# Altium schematic sheet Sheet2.SchDoc
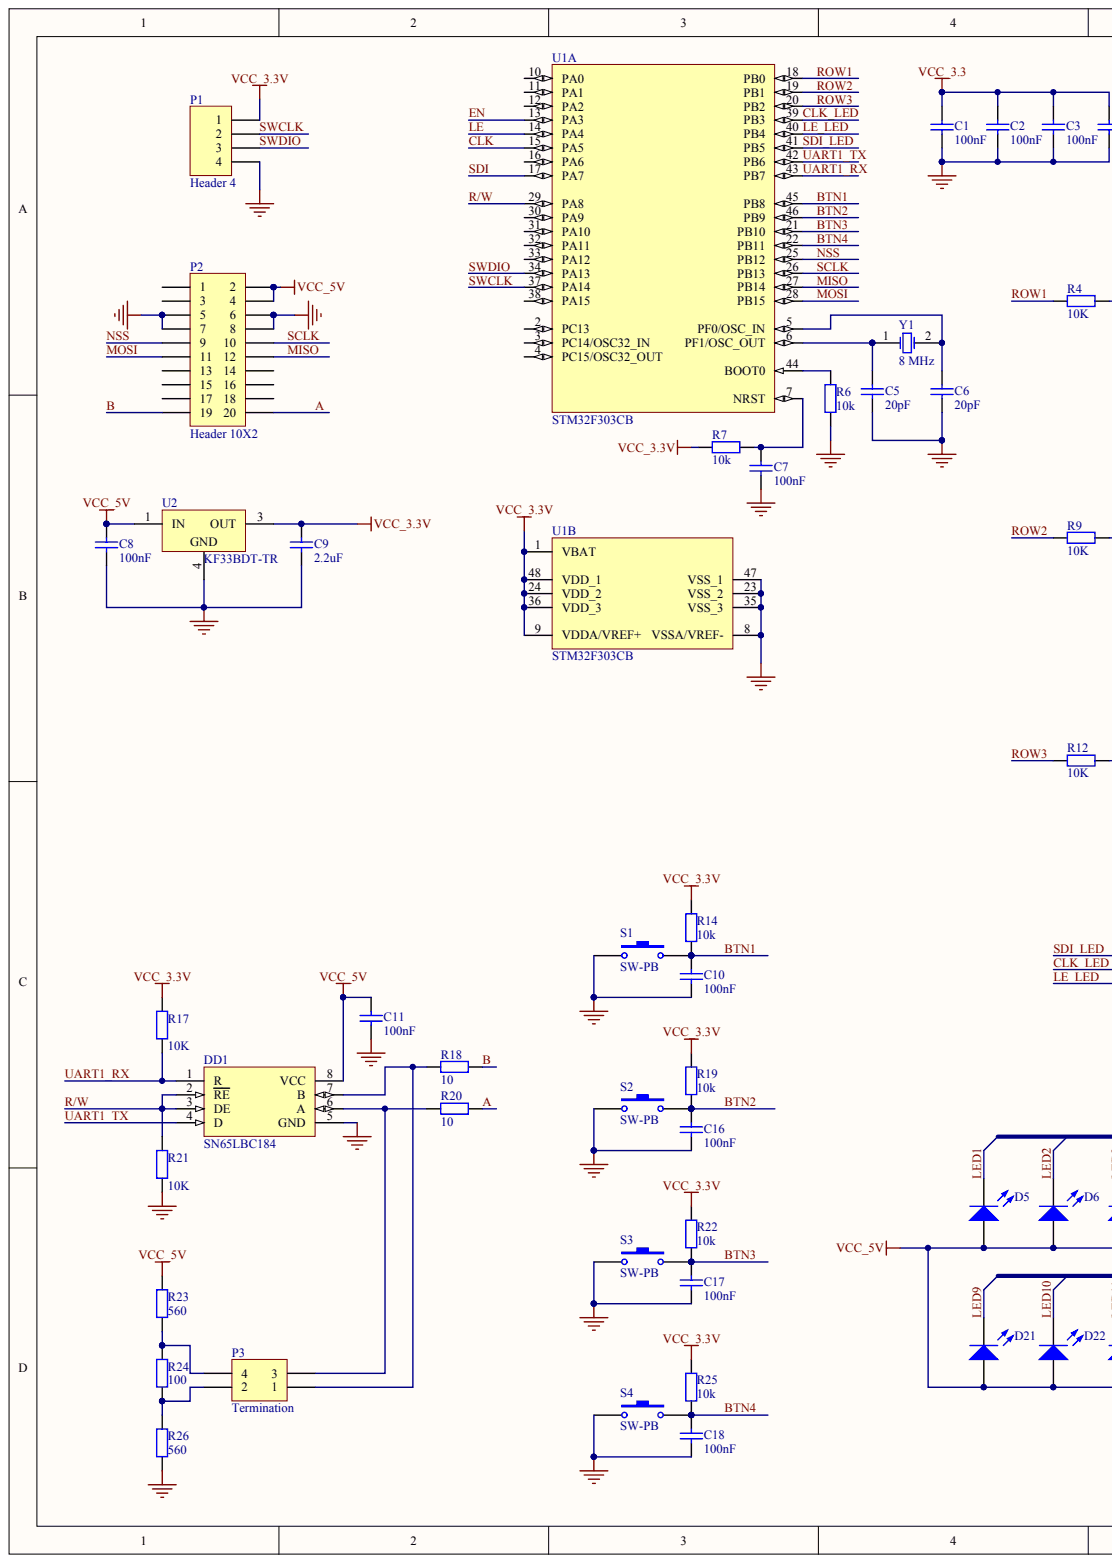
[diagram p0: Sheet2.SchDoc, left]
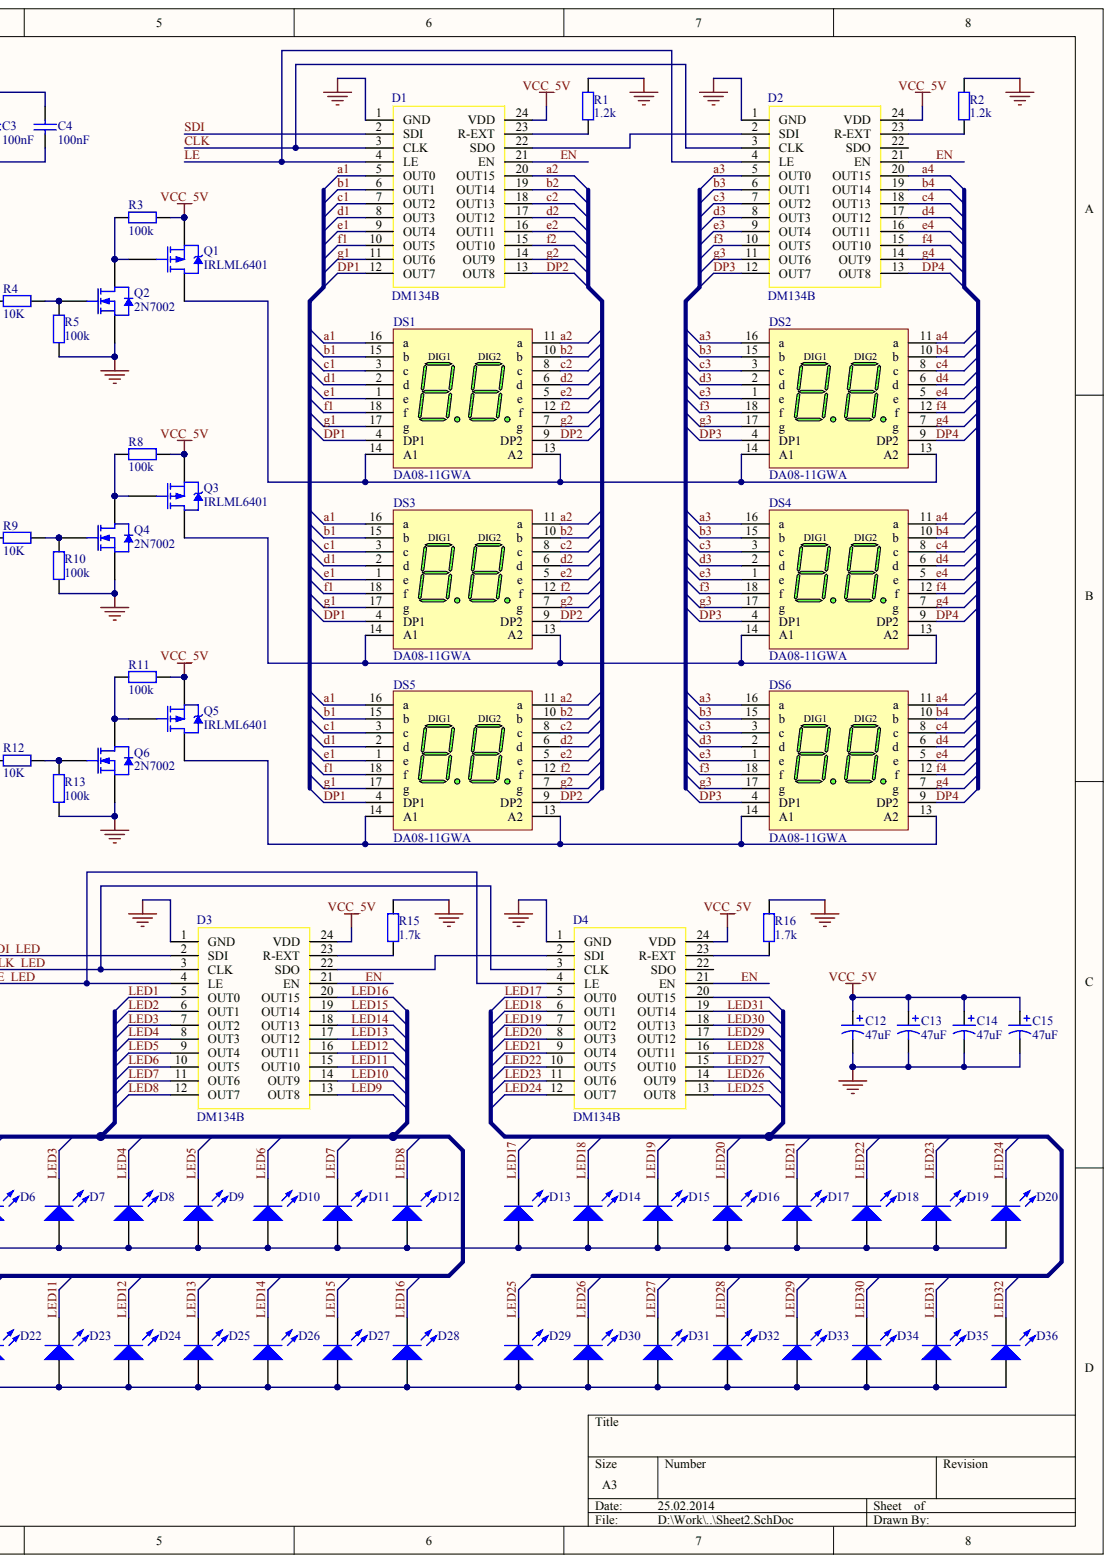
[diagram p1: Sheet2.SchDoc, right]

## Parts
C1  100nF
C2  100nF
C3  100nF
C4  100nF
C5  20pF
C6  20pF
C7  100nF
C8  100nF
C9  2.2uF
C10  100nF
C11  100nF
C12  47uF
C13  47uF
C14  47uF
C15  47uF
C16  100nF
C17  100nF
C18  100nF
D1  DM134B
D2  DM134B
D3  DM134B
D4  DM134B
D5  LED0  (value not drawn)
D6  LED0  (value not drawn)
D7  LED0  (value not drawn)
D8  LED0  (value not drawn)
D9  LED0  (value not drawn)
D10  LED0  (value not drawn)
D11  LED0  (value not drawn)
D12  LED0  (value not drawn)
D13  LED0  (value not drawn)
D14  LED0  (value not drawn)
D15  LED0  (value not drawn)
D16  LED0  (value not drawn)
D17  LED0  (value not drawn)
D18  LED0  (value not drawn)
D19  LED0  (value not drawn)
D20  LED0  (value not drawn)
D21  LED0  (value not drawn)
D22  LED0  (value not drawn)
D23  LED0  (value not drawn)
D24  LED0  (value not drawn)
D25  LED0  (value not drawn)
D26  LED0  (value not drawn)
D27  LED0  (value not drawn)
D28  LED0  (value not drawn)
D29  LED0  (value not drawn)
D30  LED0  (value not drawn)
D31  LED0  (value not drawn)
D32  LED0  (value not drawn)
D33  LED0  (value not drawn)
D34  LED0  (value not drawn)
D35  LED0  (value not drawn)
D36  LED0  (value not drawn)
DD1  SN65LBC184
DS1  DA08-11GWA
DS2  DA08-11GWA
DS3  DA08-11GWA
DS4  DA08-11GWA
DS5  DA08-11GWA
DS6  DA08-11GWA
P1  Header 4
P2  Header 10X2
P3  Termination
Q1  IRLML6401
Q2  2N7002
Q3  IRLML6401
Q4  2N7002
Q5  IRLML6401
Q6  2N7002
R1  1.2k
R2  1.2k
R3  100k
R4  10K
R5  100k
R6  10k
R7  10k
R8  100k
R9  10K
R10  100k
R11  100k
R12  10K
R13  100k
R14  10k
R15  1.7k
R16  1.7k
R17  value 10K  (hidden comment: Res2)
R18  value 10  (hidden comment: Res2)
R19  10k
R20  value 10  (hidden comment: Res2)
R21  value 10K  (hidden comment: Res2)
R22  10k
R23  value 560  (hidden comment: Res2)
R24  value 100  (hidden comment: Res2)
R25  10k
R26  value 560  (hidden comment: Res2)
S1  SW-PB
S2  SW-PB
S3  SW-PB
S4  SW-PB
U1  STM32F303CB  (parts A,B)
U2  KF33BDT-TR
Y1  8 MHz

## Nets
VCC_3.3: C1.2 C2.2 C3.2 C4.2
VCC_3.3V: C9.2 P1.1 R7.1 R14.1 R17.2 R19.1 R22.1 R25.1 U1.1(VBAT) U1.9(VDDA/VREF+) U1.24(VDD_2) U1.36(VDD_3) U1.48(VDD_1) U2.3
VCC_5V: C8.2 C11.1 C12.1 C13.1 C14.1 C15.1 D1.24(VDD) D2.24(VDD) D3.24(VDD) D4.24(VDD) D5.1 D6.1 D7.1 D8.1 D9.1 D10.1 D11.1 D12.1 D13.1 D14.1 D15.1 D16.1 D17.1 D18.1 D19.1 D20.1 D21.1 D22.1 D23.1 D24.1 D25.1 D26.1 D27.1 D28.1 D29.1 D30.1 D31.1 D32.1 D33.1 D34.1 D35.1 D36.1 DD1.8(VCC) P2.2 P2.4 Q1.2 Q3.2 Q5.2 R3.2 R8.2 R11.2 R23.1 U2.1
: C1.1 C2.1 C3.1 C4.1 C5.1 C6.1 C7.1 C8.1 C9.1 C10.1 C11.2 C12.2 C13.2 C14.2 C15.2 C16.1 C17.1 C18.1 D1.1(GND) D2.1(GND) D3.1(GND) D4.1(GND) DD1.5(GND) P1.4 P2.5 P2.6 P2.7 P2.8 Q2.2 Q4.2 Q6.2 R1.1 R2.1 R5.1 R6.1 R10.1 R13.1 R15.1 R16.1 R21.1 R26.2 S1.2 S2.2 S3.2 S4.2 U1.8(VSSA/VREF-) U1.23(VSS_2) U1.35(VSS_3) U1.47(VSS_1) U2.4
A: P2.20 R20.1
A1: D1.5(OUT0) DS1.16(a) DS3.16(a) DS5.16(a)
A2: D1.20(OUT15) DS1.11(a) DS3.11(a) DS5.11(a)
A3: D2.5(OUT0) DS2.16(a) DS4.16(a) DS6.16(a)
A4: D2.20(OUT15) DS2.11(a) DS4.11(a) DS6.11(a)
B: P2.19 R18.1
B1: D1.6(OUT1) DS1.15(b) DS3.15(b) DS5.15(b)
B2: D1.19(OUT14) DS1.10(b) DS3.10(b) DS5.10(b)
B3: D2.6(OUT1) DS2.15(b) DS4.15(b) DS6.15(b)
B4: D2.19(OUT14) DS2.10(b) DS4.10(b) DS6.10(b)
BTN1: C10.2 R14.2 S1.1 U1.45(PB8)
BTN2: C16.2 R19.2 S2.1 U1.46(PB9)
BTN3: C17.2 R22.2 S3.1 U1.21(PB10)
BTN4: C18.2 R25.2 S4.1 U1.22(PB11)
C1: D1.7(OUT2) DS1.3(c) DS3.3(c) DS5.3(c)
C2: D1.18(OUT13) DS1.8(c) DS3.8(c) DS5.8(c)
C3: D2.7(OUT2) DS2.3(c) DS4.3(c) DS6.3(c)
C4: D2.18(OUT13) DS2.8(c) DS4.8(c) DS6.8(c)
CLK: D1.3(CLK) D2.3(CLK) U1.15(PA5)
CLK_LED: D3.3(CLK) D4.3(CLK) U1.39(PB3)
D1: D1.8(OUT3) DS1.2(d) DS3.2(d) DS5.2(d)
D2: D1.17(OUT12) DS1.6(d) DS3.6(d) DS5.6(d)
D3: D2.8(OUT3) DS2.2(d) DS4.2(d) DS6.2(d)
D4: D2.17(OUT12) DS2.6(d) DS4.6(d) DS6.6(d)
DP1: D1.12(OUT7) DS1.4(DP1) DS3.4(DP1) DS5.4(DP1)
DP2: D1.13(OUT8) DS1.9(DP2) DS3.9(DP2) DS5.9(DP2)
DP3: D2.12(OUT7) DS2.4(DP1) DS4.4(DP1) DS6.4(DP1)
DP4: D2.13(OUT8) DS2.9(DP2) DS4.9(DP2) DS6.9(DP2)
E1: D1.9(OUT4) DS1.1(e) DS3.1(e) DS5.1(e)
E2: D1.16(OUT11) DS1.5(e) DS3.5(e) DS5.5(e)
E3: D2.9(OUT4) DS2.1(e) DS4.1(e) DS6.1(e)
E4: D2.16(OUT11) DS2.5(e) DS4.5(e) DS6.5(e)
EN: D1.21(EN) D2.21(EN) D3.21(EN) D4.21(EN) U1.13(PA3)
F1: D1.10(OUT5) DS1.18(f) DS3.18(f) DS5.18(f)
F2: D1.15(OUT10) DS1.12(f) DS3.12(f) DS5.12(f)
F3: D2.10(OUT5) DS2.18(f) DS4.18(f) DS6.18(f)
F4: D2.15(OUT10) DS2.12(f) DS4.12(f) DS6.12(f)
G1: D1.11(OUT6) DS1.17(g) DS3.17(g) DS5.17(g)
G2: D1.14(OUT9) DS1.7(g) DS3.7(g) DS5.7(g)
G3: D2.11(OUT6) DS2.17(g) DS4.17(g) DS6.17(g)
G4: D2.14(OUT9) DS2.7(g) DS4.7(g) DS6.7(g)
LE: D1.4(LE) D2.4(LE) U1.14(PA4)
LE_LED: D3.4(LE) D4.4(LE) U1.40(PB4)
LED1: D3.5(OUT0) D5.2
LED2: D3.6(OUT1) D6.2
LED3: D3.7(OUT2) D7.2
LED4: D3.8(OUT3) D8.2
LED5: D3.9(OUT4) D9.2
LED6: D3.10(OUT5) D10.2
LED7: D3.11(OUT6) D11.2
LED8: D3.12(OUT7) D12.2
LED9: D3.13(OUT8) D21.2
LED10: D3.14(OUT9) D22.2
LED11: D3.15(OUT10) D23.2
LED12: D3.16(OUT11) D24.2
LED13: D3.17(OUT12) D25.2
LED14: D3.18(OUT13) D26.2
LED15: D3.19(OUT14) D27.2
LED16: D3.20(OUT15) D28.2
LED17: D4.5(OUT0) D13.2
LED18: D4.6(OUT1) D14.2
LED19: D4.7(OUT2) D15.2
LED20: D4.8(OUT3) D16.2
LED21: D4.9(OUT4) D17.2
LED22: D4.10(OUT5) D18.2
LED23: D4.11(OUT6) D19.2
LED24: D4.12(OUT7) D20.2
LED25: D4.13(OUT8) D29.2
LED26: D4.14(OUT9) D30.2
LED27: D4.15(OUT10) D31.2
LED28: D4.16(OUT11) D32.2
LED29: D4.17(OUT12) D33.2
LED30: D4.18(OUT13) D34.2
LED31: D4.19(OUT14) D35.2
LED32: D36.2
MISO: P2.12 U1.27(PB14)
MOSI: P2.11 U1.28(PB15)
NSS: P2.9 U1.25(PB12)
R/W: DD1.2(RE) DD1.3(DE) R21.2 U1.29(PA8)
ROW1: R4.1 U1.18(PB0)
ROW2: R9.1 U1.19(PB1)
ROW3: R12.1 U1.20(PB2)
SCLK: P2.10 U1.26(PB13)
SDI: D1.2(SDI) U1.17(PA7)
SDI_LED: D3.2(SDI) U1.41(PB5)
SWCLK: P1.2 U1.37(PA14)
SWDIO: P1.3 U1.34(PA13)
UART1_RX: DD1.1(R) R17.1 U1.43(PB7)
UART1_TX: DD1.4(D) U1.42(PB6)
NetC5_2: C5.2 U1.6(PF1/OSC_OUT) Y1.1
NetC6_2: C6.2 U1.5(PF0/OSC_IN) Y1.2
NetC7_2: C7.2 R7.2 U1.7(NRST)
NetD1_22: D1.22(SDO) D2.2(SDI)
NetD1_23: D1.23(R-EXT) R1.2
NetD2_23: D2.23(R-EXT) R2.2
NetD3_22: D3.22(SDO) D4.2(SDI)
NetD3_23: D3.23(R-EXT) R15.2
NetD4_23: D4.23(R-EXT) R16.2
NetDD1_6: DD1.6(A) P3.3 R20.2
NetDD1_7: DD1.7(B) P3.1 R18.2
NetDS1_13: DS1.13(A2) DS1.14(A1) DS2.13(A2) DS2.14(A1) Q1.3
NetDS3_13: DS3.13(A2) DS3.14(A1) DS4.13(A2) DS4.14(A1) Q3.3
NetDS5_13: DS5.13(A2) DS5.14(A1) DS6.13(A2) DS6.14(A1) Q5.3
NetP3_2: P3.2 R24.2 R26.1
NetP3_4: P3.4 R23.2 R24.1
NetQ1_1: Q1.1 Q2.3 R3.1
NetQ2_1: Q2.1 R4.2 R5.2
NetQ3_1: Q3.1 Q4.3 R8.1
NetQ4_1: Q4.1 R9.2 R10.2
NetQ5_1: Q5.1 Q6.3 R11.1
NetQ6_1: Q6.1 R12.2 R13.2
NetR6_2: R6.2 U1.44(BOOT0)
N00079:
N00080:
N00081:
N00082:
N00083:
N00084:

Not connected: D2 22(SDO); D4 20(OUT15) 22(SDO); P2 1 3 13 14 15 16 17 18; U1 2(PC13) 3(PC14/OSC32_IN) 4(PC15/OSC32_OUT) 10(PA0) 11(PA1) 12(PA2) 16(PA6) 30(PA9) 31(PA10) 32(PA11) 33(PA12) 38(PA15)

## Library data of the parts
C1-C4 C7-C11 C16-C18 = (no symbol name): Value: 0.1uF; Published: 8-Jun-2000; LatestRevisionDate: 17-Jul-2002; LatestRevisionNote: Re-released for DXP Platform.; PackageReference: RAD-0.3; PackageDescription: Radial Cap, Thru-Hole; 2 Leads; 0.3 in Pin Spacing; Publisher: Altium Limited
C5 C6 = (no symbol name): Value: 100pF; Published: 8-Jun-2000; LatestRevisionDate: 17-Jul-2002; LatestRevisionNote: Re-released for DXP Platform.; PackageReference: RAD-0.3; PackageDescription: Radial Cap, Thru-Hole; 2 Leads; 0.3 in Pin Spacing; Publisher: Altium Limited
C12-C15 = (no symbol name): Note: See Data Sheet for full range of components; Published: 19-Mar-2004; DatasheetDocument: 05-Jul-2002; PackageReference: 49BC-P; ComponentLink1Description: Manufacturer Link; ComponentLink1URL: http://www.vishay.com/; Value: 47uF; Publisher: Altium Limited
D1-D4 = (no symbol name): Type: LED Driver
R1 R2 R15 R16 = (no symbol name): Value: 4K7; Published: 8-Jun-2000; LatestRevisionDate: 17-Jul-2002; LatestRevisionNote: Re-released for DXP Platform.; PackageReference: AXIAL-0.4; PackageDescription: Axial Device, Thru-Hole; 2 Leads; 0.4 in Pin Spacing; Publisher: Altium Limited
R3 R5 R8 R10 R11 R13 = (no symbol name): Value: 1K; Published: 8-Jun-2000; LatestRevisionDate: 17-Jul-2002; LatestRevisionNote: Re-released for DXP Platform.; PackageReference: AXIAL-0.4; PackageDescription: Axial Device, Thru-Hole; 2 Leads; 0.4 in Pin Spacing; Publisher: Altium Limited
D5-D36 DD1 DS1-DS6 P1-P3 Q1-Q6 R4 R6 R7 R9 R12 R14 R17-R26 S1-S4 U1 U2 Y1 = (no symbol name)
  D5-D36: Published: 8-Jun-2000; LatestRevisionDate: 08-Jul-2005; LatestRevisionNote: Mech Layer 1 removed.; PackageReference: LED-0; PackageDescription: LED; 2 Leads
  P1-P3: LatestRevisionDate: 17-Jul-2002; LatestRevisionNote: Re-released for DXP Platform.
  Q1 Q3 Q5: Published: 17-Sep-2003; DatasheetVersion: 2-Jul-2001; PackageVersion: Jul-2001; PackageReference: Micro3; PackageDescription: 3-Pin SOT-23 Package 0.95 mm Pitch, 2.3 mm Lead Span; ComponentLink1Description: Manufacturer Link; ComponentLink1URL: http://www.irf.com/; ComponentLink2Description: Datasheet; ComponentLink2URL: http://www.irf.com/product-info/datasheets/data/IRLML6401.pdf
  Q2 Q4 Q6: Published: 17-Sep-2003; LatestRevisionDate: 12-Dec-2006; LatestRevisionNote: IPC-7351 Footprint Added.; DatasheetVersion: 27-Jul-2000; PackageVersion: May-1999; PackageReference: SOT23; PackageDescription: 3-Pin SOT-23 Package 0.95 mm Pitch, 2.3 mm Lead Span; ComponentLink1Description: Manufacturer Link; ComponentLink1URL: http://www.semiconductors.philips.com/; ComponentLink2Description: Datasheet; ComponentLink2URL: http://www.semiconductors.philips.com/acrobat/datasheets/2N7002_4.pdf
  R4 R6 R9 R12 R14 R19 R22 R25: Published: 8-Jun-2000; LatestRevisionDate: 17-Jul-2002; LatestRevisionNote: Re-released for DXP Platform.; PackageReference: AXIAL-0.4; PackageDescription: Axial Device, Thru-Hole; 2 Leads; 0.4 in Pin Spacing; Value: 10K
  R7: Published: 8-Jun-2000; LatestRevisionDate: 17-Jul-2002; LatestRevisionNote: Re-released for DXP Platform.; PackageReference: AXIAL-0.4; PackageDescription: Axial Device, Thru-Hole; 2 Leads; 0.4 in Pin Spacing; Value: 10k
  R17 R18 R20 R21 R23 R24 R26: Published: 8-Jun-2000; LatestRevisionDate: 17-Jul-2002; LatestRevisionNote: Re-released for DXP Platform.; PackageReference: AXIAL-0.4; PackageDescription: Axial Device, Thru-Hole; 2 Leads; 0.4 in Pin Spacing
  S1-S4: Published: 24-Mar-1999; LatestRevisionDate: 17-Jul-2002; LatestRevisionNote: Re-released for DXP Platform.; PackageReference: SPST-2; PackageDescription: Switch; 2 Leads
  U1: DatasheetVersion: rev6.0, Apr-2011; PackageVersion: rev13, Apr-2011; PackageReference: LQFP48; PackageDescription: 48-Pin Low-Profile Quad Flat Pack, 7 x 7 mm Body, 0.5 mm Pitch (LQFP48); ComponentLink1Description: Manufacturer URL; ComponentLink1URL: http://www.st.com/; ComponentLink2Description: STM32F303 Datasheet; ComponentLink2URL: http://www.st.com/st-web-ui/static/active/en/resource/technical/document/datasheet/DM00058181.pdf; ComponentLink3Description: STM32 Family Web Page; ComponentLink3URL: www.st.com/stm32
  U2: DatasheetVersion: Feb-2008; PackageVersion: 2011; PackageReference: TO-252; PackageDescription: 3-Pin Transistor Outline Package 2.28 mm Pitch, 9.7 mm Lead Span (DPAK); PartNumber: KF33BDT-TR; ComponentLink1Description: Manufacturer URL; ComponentLink1URL: http://www.st.com; ComponentLink2Description: Datasheet; ComponentLink2URL: http://www.st.com/internet/com/TECHNICAL_RESOURCES/TECHNICAL_LITERATURE/DATASHEET/CD00000970.pdf
  Y1: Published: 8-Jun-2000; LatestRevisionDate: 17-Jul-2002; LatestRevisionNote: Re-released for DXP Platform.; PackageReference: R38; PackageDescription: Crystal, Thru-Hole; 2 Leads; Body 3.1 x 8.2 mm (Dia.xH)
  D5-D36 P1-P3 Q1-Q6 R4 R6 R7 R9 R12 R14 R17-R26 S1-S4 Y1: Publisher: Altium Limited
